# Altium schematic sheet BaseBoard.SchDoc(BaseBoard)
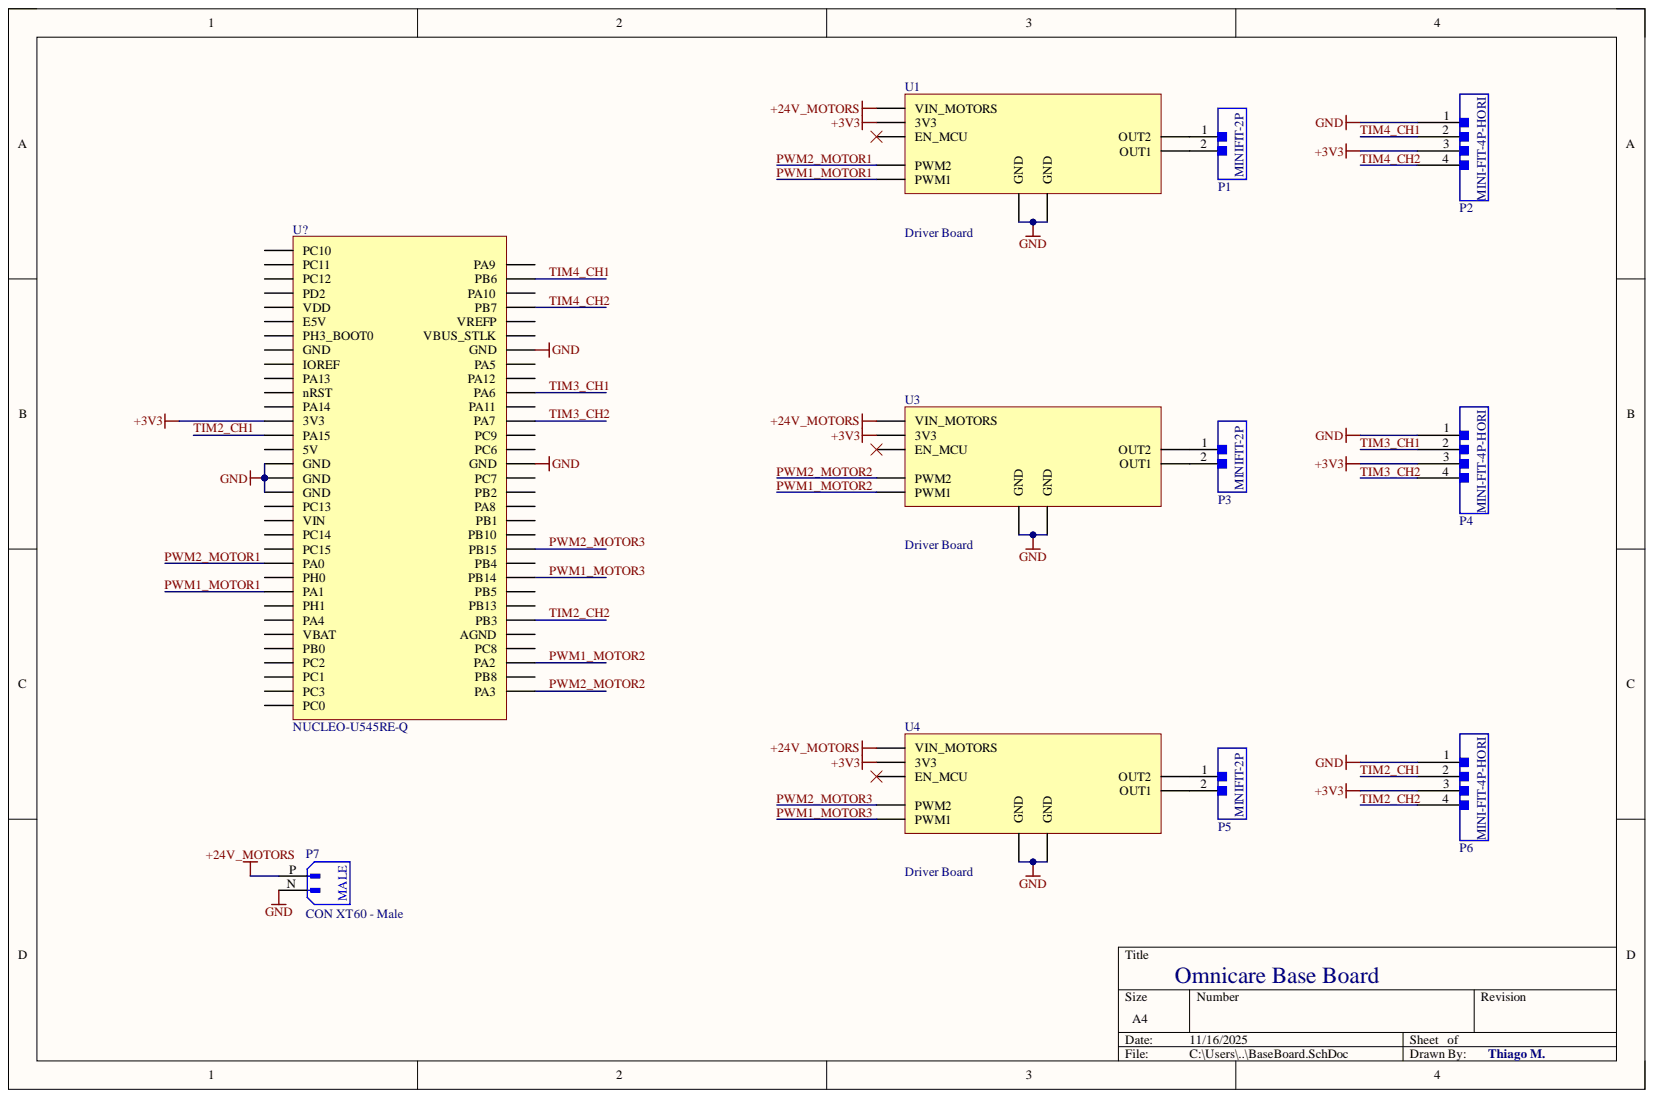
[diagram p0: BaseBoard.SchDoc]

## Parts
P1  CON MINI FIT 2P - 1x2  [CON MINI FIT 2 POS - 1x2 - 90DG]  (value not drawn)
P2  CON MINI FIT 4P - 2x2 - HORIZONTAL  [CON MINI FIT 4 POS - 2x2 - 90DG]  (value not drawn)
P3  CON MINI FIT 2P - 1x2  [CON MINI FIT 2 POS - 1x2 - 90DG]  (value not drawn)
P4  CON MINI FIT 4P - 2x2 - HORIZONTAL  [CON MINI FIT 4 POS - 2x2 - 90DG]  (value not drawn)
P5  CON MINI FIT 2P - 1x2  [CON MINI FIT 2 POS - 1x2 - 90DG]  (value not drawn)
P6  CON MINI FIT 4P - 2x2 - HORIZONTAL  [CON MINI FIT 4 POS - 2x2 - 90DG]  (value not drawn)
P7  CON XT60 - Male  [CON XT60 MALE]
U1  Driver Board  [Driver Board]
U3  Driver Board  [Driver Board]
U4  Driver Board  [Driver Board]
U?  NUCLEO-U545RE-Q  [NUCLEO64]

## Nets
+3V3: P2.3 P4.3 P6.3 U1.2(3V3) U3.2(3V3) U4.2(3V3) U?.CN7_16, CN6_4(3V3)
+24V_MOTORS: P7.P U1.3, 4, 5, 6(VIN_MOTORS) U3.3, 4, 5, 6(VIN_MOTORS) U4.3, 4, 5, 6(VIN_MOTORS)
GND: P2.1 P4.1 P6.1 P7.N U1.9, 10(GND) U1.23, 24, 25, 26(GND) U3.9, 10(GND) U3.23, 24, 25, 26(GND) U4.9, 10(GND) U4.23, 24, 25, 26(GND) U?.CN7_19(GND) U?.CN7_20(GND) U?.CN7_22(GND) U?.CN10_9(GND) U?.CN10_20(GND)
PWM1_MOTOR1: U1.7(PWM1) U?.CN7_30(PA1)
PWM1_MOTOR2: U3.7(PWM1) U?.CN10_35(PA2)
PWM1_MOTOR3: U4.7(PWM1) U?.CN10_28(PB14)
PWM2_MOTOR1: U1.8(PWM2) U?.CN7_28(PA0)
PWM2_MOTOR2: U3.8(PWM2) U?.CN10_37(PA3)
PWM2_MOTOR3: U4.8(PWM2) U?.CN10_26(PB15)
TIM2_CH1: P6.2 U?.CN7_17(PA15)
TIM2_CH2: P6.4 U?.CN10_31(PB3)
TIM3_CH1: P4.2 U?.CN10_13(PA6)
TIM3_CH2: P4.4 U?.CN10_15(PA7)
TIM4_CH1: P2.2 U?.CN10_3(PB6)
TIM4_CH2: P2.4 U?.CN10_5(PB7)
NetP1_1: P1.1 U1.21, 22(OUT2)
NetP1_2: P1.2 U1.27, 28(OUT1)
NetP3_1: P3.1 U3.21, 22(OUT2)
NetP3_2: P3.2 U3.27, 28(OUT1)
NetP5_1: P5.1 U4.21, 22(OUT2)
NetP5_2: P5.2 U4.27, 28(OUT1)

Not connected: U1 1(EN_MCU); U3 1(EN_MCU); U4 1(EN_MCU); U? CN7_1(PC10) CN7_2(PC11) CN7_3(PC12) CN7_4(PD2) CN7_5(VDD) CN7_6(E5V) CN7_7(PH3_BOOT0) CN7_8(GND) CN7_12(IOREF) CN7_13(PA13) CN7_14(nRST) CN7_15(PA14) CN7_18(5V) CN7_23(PC13) CN7_24(VIN) CN7_25(PC14) CN7_27(PC15) CN7_29(PH0) CN7_31(PH1) CN7_32(PA4) CN7_33(VBAT) CN7_34(PB0) CN7_35(PC2) CN7_36(PC1) CN7_37(PC3) CN7_38(PC0) CN10_2(PA9) CN10_4(PA10) CN10_7(VREFP) CN10_8(VBUS_STLK) CN10_11(PA5) CN10_12(PA12) CN10_14(PA11) CN10_17(PC9) CN10_19(PC6) CN10_21(PC7) CN10_22(PB2) CN10_23(PA8) CN10_24(PB1) CN10_25(PB10) CN10_27(PB4) CN10_29(PB5) CN10_30(PB13) CN10_32(AGND) CN10_33(PC8) CN10_36(PB8)

## Library data of the parts
P1 P3 P5 = CON MINI FIT 2P - 1x2: CONECTOR - MINI-FIT 2 pinos - 180/90 graus - 4.2mm; Component Kind: Standard; Library Name: My_Lib.IntLib
P2 P4 P6 = CON MINI FIT 4P - 2x2 - HORIZONTAL: CONECTOR - MINI-FIT 4 pinos - 90 graus - 4.2mm; Component Kind: Standard; Library Name: My_Lib.IntLib
U1 U3 U4 = Driver Board: IC Module - Driver Board STSPIN958; Component Kind: Standard; Library Name: My_Lib.IntLib
P7 = CON XT60 - Male: Component Kind: Standard; Library Name: My_Lib.IntLib
U? = NUCLEO-U545RE-Q: Component Kind: Standard; Library Name: My_Lib.IntLib
